# Altium schematic sheet Video.SchDoc
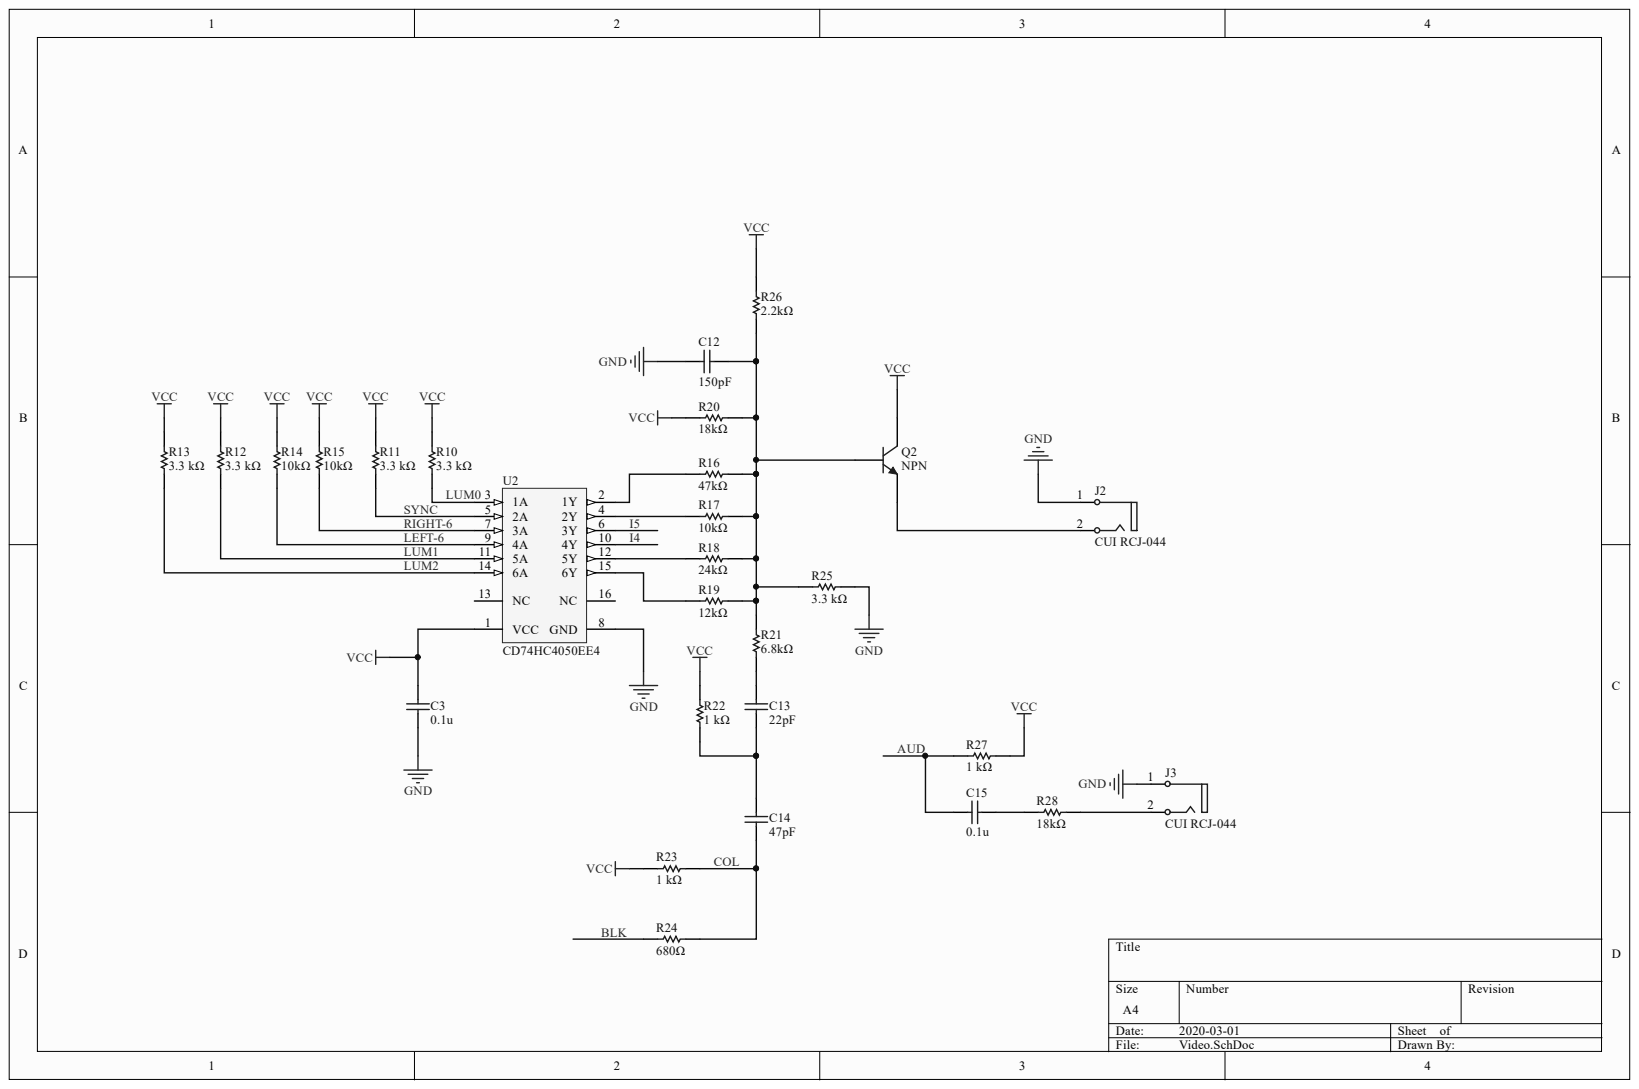
[diagram p0: Video.SchDoc]

## Parts
C3  Capacitance: 0.1u  [CAPC2013X140X45ML15T25]  (hidden comment: Murata GCM21BR71E104KA37L)
C12  Capacitance: 150pF  [CAPC2013X140X45ML15T25]  (hidden comment: Murata GCM21BR71E104KA37L)
C13  Capacitance: 22pF  [CAPC2013X140X45ML15T25]  (hidden comment: Murata GCM21BR71E104KA37L)
C14  Capacitance: 47pF  [CAPC2013X140X45ML15T25]  (hidden comment: Murata GCM21BR71E104KA37L)
C15  Capacitance: 0.1u  [CAPC2013X140X45ML15T25]  (hidden comment: Murata GCM21BR71E104KA37L)
J2  CUI RCJ-044  [PCB-xlxgxx5v06aiivh5o5a0-1]
J3  CUI RCJ-044  [PCB-xlxgxx5v06aiivh5o5a0-1]
Q2  NPN  [PCB-fs5arr6b0j1qpwda469g-1]
R10  Resistance: 3.3 kΩ  [PCB-jqva0n1m8ejtn3mkjxws-1]  (hidden comment: TE Connectivity LR1F10K)
R11  Resistance: 3.3 kΩ  [PCB-jqva0n1m8ejtn3mkjxws-1]  (hidden comment: TE Connectivity LR1F10K)
R12  Resistance: 3.3 kΩ  [PCB-jqva0n1m8ejtn3mkjxws-1]  (hidden comment: TE Connectivity LR1F10K)
R13  Resistance: 3.3 kΩ  [PCB-jqva0n1m8ejtn3mkjxws-1]  (hidden comment: TE Connectivity LR1F10K)
R14  Resistance: 10kΩ  [PCB-jqva0n1m8ejtn3mkjxws-1]  (hidden comment: TE Connectivity LR1F10K)
R15  Resistance: 10kΩ  [PCB-jqva0n1m8ejtn3mkjxws-1]  (hidden comment: TE Connectivity LR1F10K)
R16  Resistance: 47kΩ  [PCB-jqva0n1m8ejtn3mkjxws-1]  (hidden comment: TE Connectivity LR1F10K)
R17  Resistance: 10kΩ  [PCB-jqva0n1m8ejtn3mkjxws-1]  (hidden comment: TE Connectivity LR1F10K)
R18  Resistance: 24kΩ  [PCB-jqva0n1m8ejtn3mkjxws-1]  (hidden comment: TE Connectivity LR1F10K)
R19  Resistance: 12kΩ  [PCB-jqva0n1m8ejtn3mkjxws-1]  (hidden comment: TE Connectivity LR1F10K)
R20  Resistance: 18kΩ  [PCB-jqva0n1m8ejtn3mkjxws-1]  (hidden comment: TE Connectivity LR1F10K)
R21  Resistance: 6.8kΩ  [PCB-jqva0n1m8ejtn3mkjxws-1]  (hidden comment: TE Connectivity LR1F10K)
R22  Resistance: 1 kΩ  [PCB-jqva0n1m8ejtn3mkjxws-1]  (hidden comment: TE Connectivity LR1F10K)
R23  Resistance: 1 kΩ  [PCB-jqva0n1m8ejtn3mkjxws-1]  (hidden comment: TE Connectivity LR1F10K)
R24  Resistance: 680Ω  [PCB-jqva0n1m8ejtn3mkjxws-1]  (hidden comment: TE Connectivity LR1F10K)
R25  Resistance: 3.3 kΩ  [PCB-jqva0n1m8ejtn3mkjxws-1]  (hidden comment: TE Connectivity LR1F10K)
R26  Resistance: 2.2kΩ  [PCB-jqva0n1m8ejtn3mkjxws-1]  (hidden comment: TE Connectivity LR1F10K)
R27  Resistance: 1 kΩ  [PCB-jqva0n1m8ejtn3mkjxws-1]  (hidden comment: TE Connectivity LR1F10K)
R28  Resistance: 18kΩ  [PCB-jqva0n1m8ejtn3mkjxws-1]  (hidden comment: TE Connectivity LR1F10K)
U2  Manufacturer Part Number: CD74HC4050EE4  [PCB-unusc2hzd33fv2niogfj-1]  (hidden comment: SYM-b2607b788e076da7-1)

## Nets
GND: C3.2 C12.1 J2.1 J3.1 R25.2 U2.8(GND)
VCC: C3.1 Q2.1 R10.1 R11.1 R12.1 R13.1 R14.1 R15.1 R20.1 R22.2 R23.2 R26.2 R27.1 U2.1(VCC)
AUD: C15.1 R27.2
BLK: R24.2
COL: C14.1 R23.1 R24.1
I4: U2.10(4Y)
I5: U2.6(3Y)
LEFT-6: R14.2 U2.9(4A)
LUM0: R10.2 U2.3(1A)
LUM1: R12.2 U2.11(5A)
LUM2: R13.2 U2.14(6A)
RIGHT-6: R15.2 U2.7(3A)
SYNC: R11.2 U2.5(2A)
NetC12_2: C12.2 Q2.2 R16.2 R17.2 R18.2 R19.2 R20.2 R21.2 R25.1 R26.1
NetC13_1: C13.1 C14.2 R22.1
NetC13_2: C13.2 R21.1
NetC15_2: C15.2 R28.1
NetJ2_2: J2.2 Q2.3
NetJ3_2: J3.2 R28.2
NetR16_1: R16.1 U2.2(1Y)
NetR17_1: R17.1 U2.4(2Y)
NetR18_1: R18.1 U2.12(5Y)
NetR19_1: R19.1 U2.15(6Y)

Not connected: U2 13(NC) 16(NC)

## Library data of the parts
C3 C15 = CMP-135214-2: CAP CER 0.1UF 25V 10% X7R 0805; Voltage Rating (DC): 25.0 V; Capacitance Tolerance: ±10%; Case/Package: 0805; Component Kind: Standard; Dielectric Characteristic: X7R; Library Name: CommunityVault; OctopartID: e78b2f8c9518384c; Octopart Url: https://octopart.com/gcm21br71e104ka37l-murata-17651701; RoHS: Compliant
C12 = CMP-135214-2: CAP CER 150pF 25V 10% X7R 0805; Voltage Rating (DC): 25.0 V; Capacitance Tolerance: ±10%; Case/Package: 0805; Component Kind: Standard; Dielectric Characteristic: X7R; Library Name: CommunityVault; OctopartID: e78b2f8c9518384c; Octopart Url: https://octopart.com/gcm21br71e104ka37l-murata-17651701; RoHS: Compliant
C13 = CMP-135214-2: CAP CER 22pF 25V 10% X7R 0805; Voltage Rating (DC): 25.0 V; Capacitance Tolerance: ±10%; Case/Package: 0805; Component Kind: Standard; Dielectric Characteristic: X7R; Library Name: CommunityVault; OctopartID: e78b2f8c9518384c; Octopart Url: https://octopart.com/gcm21br71e104ka37l-murata-17651701; RoHS: Compliant
C14 = CMP-135214-2: CAP CER 47pF 25V 10% X7R 0805; Voltage Rating (DC): 25.0 V; Capacitance Tolerance: ±10%; Case/Package: 0805; Component Kind: Standard; Dielectric Characteristic: X7R; Library Name: CommunityVault; OctopartID: e78b2f8c9518384c; Octopart Url: https://octopart.com/gcm21br71e104ka37l-murata-17651701; RoHS: Compliant
J2 J3 = CMP-1a37d33e56f0c5f0-2: Metal Right-Angle, RCA Jack, Yellow Housing and Insulation; Gender: Female; Mounting Angle: 90.0 °; Color: Yellow; Component Kind: Standard; Library Name: CommunityVault; Orientation: Horizontal; Packaging: Bulk
Q2 = CMP-3cc2f4135df8e305-3: Transistor Npn Silicon 50v Ic=800ma To-18 Case Small Signal General Purpose Swit; Component Kind: Standard; Library Name: CommunityVault; Number of Pins: 3; RoHS: Compliant
R10-R13 R25 R26 = CMP-0a5cc3a37cecd59b-6: Res Metal Film 3.3K Ohm 1% 3/5W ±50ppm/°C Conformal AXL Thru-Hole Ammo Pack; ELV Compliant: Compliant; Component Kind: Standard; Library Name: CommunityVault; Manufacturer Part Number: LR1F10K; Packaging: Ammo Pack; REACH SVHC Compliance: No SVHC; RoHS: Compliant
R14 R15 R17 R22 R23 R27 = CMP-0a5cc3a37cecd59b-6: Res Metal Film 10K Ohm 1% 3/5W ±50ppm/°C Conformal AXL Thru-Hole Ammo Pack; ELV Compliant: Compliant; Component Kind: Standard; Library Name: CommunityVault; Manufacturer Part Number: LR1F10K; Packaging: Ammo Pack; REACH SVHC Compliance: No SVHC; RoHS: Compliant
R16 = CMP-0a5cc3a37cecd59b-6: Res Metal Film 47K Ohm 1%; ELV Compliant: Compliant; Component Kind: Standard; Library Name: CommunityVault; Manufacturer Part Number: LR1F10K; Packaging: Ammo Pack; REACH SVHC Compliance: No SVHC; RoHS: Compliant
R18 = CMP-0a5cc3a37cecd59b-6: Res Metal Film 24K Ohm 1% 3/5W; ELV Compliant: Compliant; Component Kind: Standard; Library Name: CommunityVault; Manufacturer Part Number: LR1F10K; Packaging: Ammo Pack; REACH SVHC Compliance: No SVHC; RoHS: Compliant
R19 = CMP-0a5cc3a37cecd59b-6: Res Metal Film 12K Ohm 1% 3/5W; ELV Compliant: Compliant; Component Kind: Standard; Library Name: CommunityVault; Manufacturer Part Number: LR1F10K; Packaging: Ammo Pack; REACH SVHC Compliance: No SVHC; RoHS: Compliant
R20 R28 = CMP-0a5cc3a37cecd59b-6: Res Metal Film 18K Ohm 1%; ELV Compliant: Compliant; Component Kind: Standard; Library Name: CommunityVault; Manufacturer Part Number: LR1F10K; Packaging: Ammo Pack; REACH SVHC Compliance: No SVHC; RoHS: Compliant
R21 = CMP-0a5cc3a37cecd59b-6: Res Metal Film 6.8K Ohm 1% 3/5W ±50ppm/°C Conformal AXL Thru-Hole Ammo Pack; ELV Compliant: Compliant; Component Kind: Standard; Library Name: CommunityVault; Manufacturer Part Number: LR1F10K; Packaging: Ammo Pack; REACH SVHC Compliance: No SVHC; RoHS: Compliant
R24 = CMP-0a5cc3a37cecd59b-6: Res Metal Film 680 Ohm 1% 3/5W; ELV Compliant: Compliant; Component Kind: Standard; Library Name: CommunityVault; Manufacturer Part Number: LR1F10K; Packaging: Ammo Pack; REACH SVHC Compliance: No SVHC; RoHS: Compliant
U2 = CMP-b2607b788e076da7-1: High Speed CMOS Logic Hex Non-Inverting Buffers 16-PDIP -55 to 125; Output Current Drive: -1.00 mA; Propagation Delay Max (tpd): 21.0 ns; Supply Voltage (DC): 2.00 V to 6.00 V; Component Kind: Standard; Library Name: CommunityVault; Lifecycle Status: Active; Number of Pins: 16
